# Altium schematic sheet printer.SchDoc
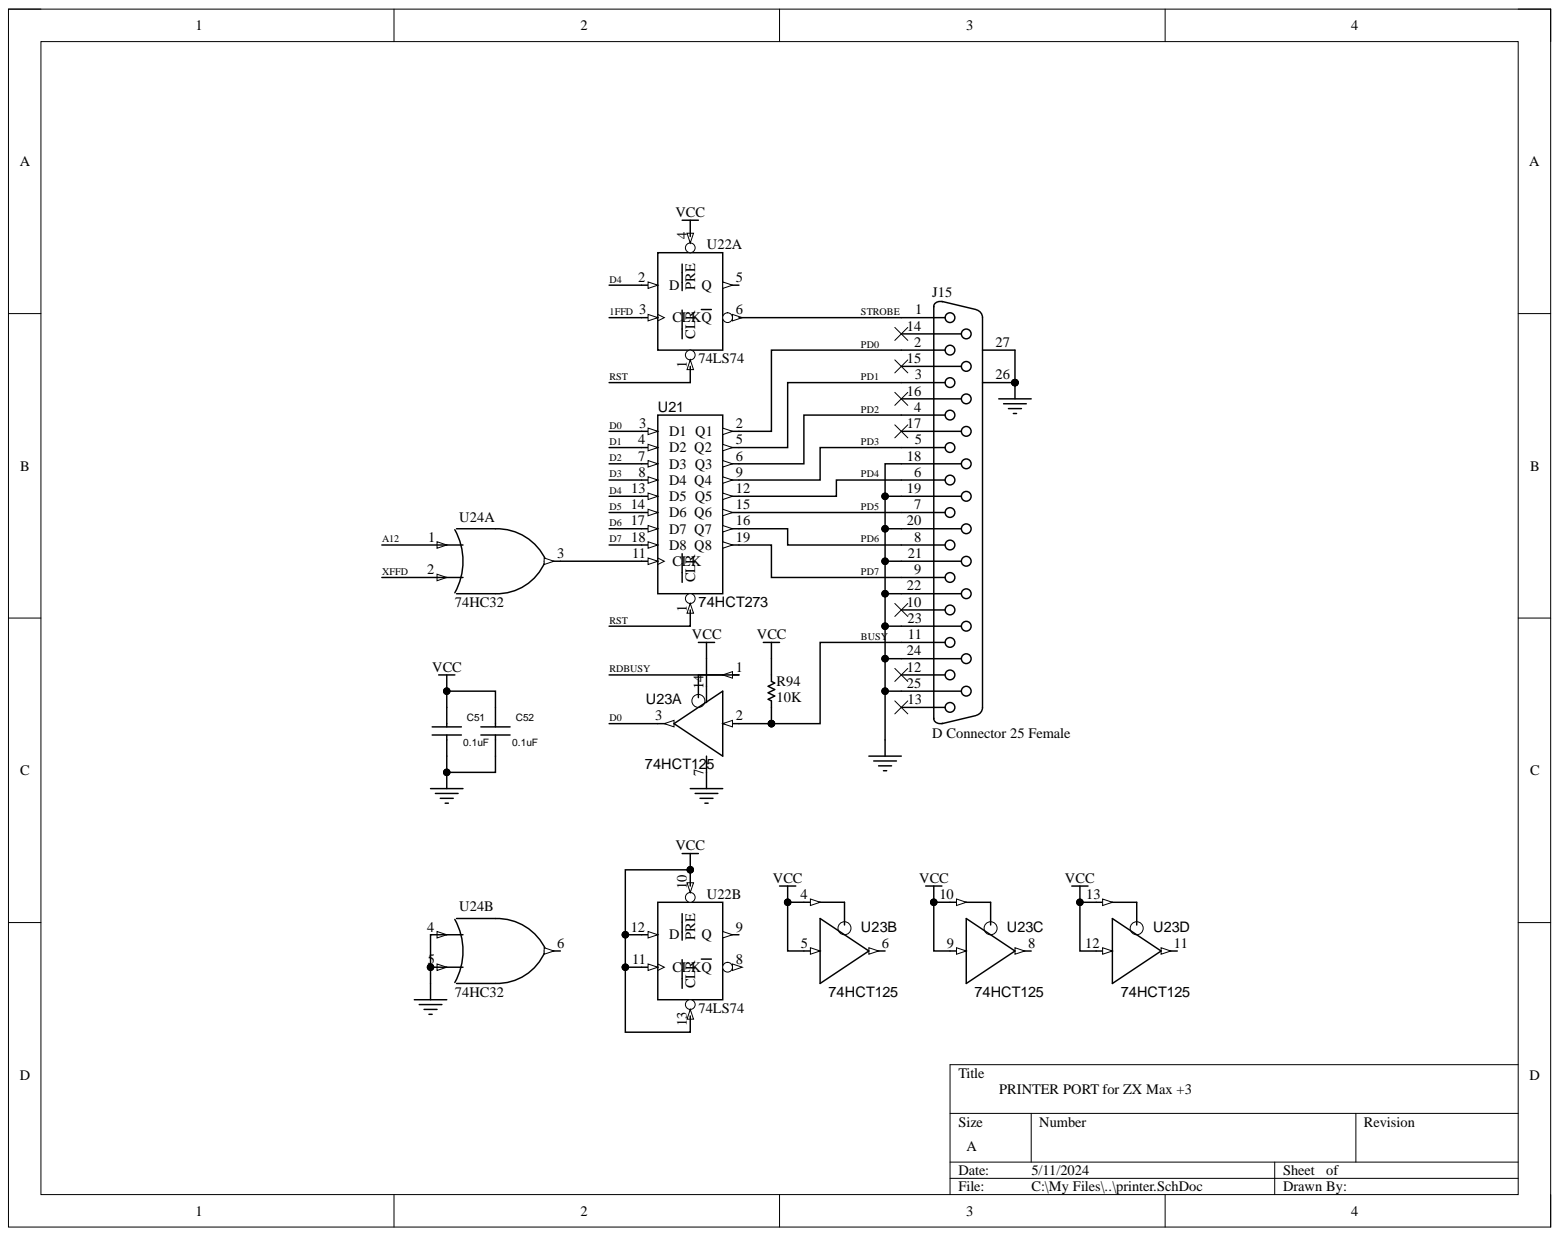
[diagram p0: printer.SchDoc]

## Parts
C51  0.1uF  [RAD/.200X.100/LS.100/.031]
C52  0.1uF  [RAD/.200X.100/LS.100/.031]
J15  D Connector 25 Female  [DSUB1.385-2H25A]
R94  10K  [AX/.350X.100/.034]
U21  74HCT273  [DIP.100/20/W.300/L.975]
U22  74LS74  [DIP.100/14/W.300/L.700]  (parts A,B)
U23  74HCT125  [DIP.100/14/W.300/L.700]  (parts A,B,C,D)
U24  74HC32  [DIP.100/14/W.300/L.700]  (parts A,B)

## Nets
GND: C51.2 C52.2 J15.18 J15.19 J15.20 J15.21 J15.22 J15.23 J15.24 J15.25 J15.26 J15.27 U21.10 U22.7 U23.7 U24.4 U24.5 U24.7
VCC: C51.1 C52.1 R94.1 U21.20 U22.4(PRE) U22.10(PRE) U22.11(CLK) U22.12(D) U22.13(CLR) U22.14 U23.4 U23.5 U23.9 U23.10 U23.12 U23.13 U23.14 U24.14
1FFD: U22.3(CLK)
A12: U24.1
BUSY: J15.11 R94.2 U23.2
D0: U21.3(D1) U23.3
D1: U21.4(D2)
D2: U21.7(D3)
D3: U21.8(D4)
D4: U21.13(D5) U22.2(D)
D5: U21.14(D6)
D6: U21.17(D7)
D7: U21.18(D8)
PD0: J15.2 U21.2(Q1)
PD1: J15.3 U21.5(Q2)
PD2: J15.4 U21.6(Q3)
PD3: J15.5 U21.9(Q4)
PD4: J15.6 U21.12(Q5)
PD5: J15.7 U21.15(Q6)
PD6: J15.8 U21.16(Q7)
PD7: J15.9 U21.19(Q8)
RDBUSY: U23.1
RST: U21.1(CLR) U22.1(CLR)
STROBE: J15.1 U22.6(Q)
XFFD: U24.2
NetU21_11: U21.11(CLK) U24.3

Not connected: J15 10 12 13 14 15 16 17; U22 5(Q) 8(Q) 9(Q); U23 6 8 11; U24 6

## Library data of the parts
C51 C52 = CAP NP: COMPFIXED: NO; COMPGROUP: 0; COMPHEIGHT: 0.000; COMPLOC: [6275.000,975.000]; COMPSIDE: TOP; FPLIST: RAD/.150X.100/LS.100/.031; COMPKEY: NO; COMPLOCKED: NO; Component Kind: Standard; COMPROT: 0; DECOUPLER: NO; MEMORY: NO
J15 = D Connector 25: Receptacle Assembly, 25 Position, Right Angle; PackageDocument: U; Positions: 25; Component Kind: Standard; DatasheetDocument: 19-Mar-1999; Gender: Receptacle; LatestRevisionDate: 17-Jul-2002; LatestRevisionNote: Re-released for DXP Platform.; Library Name: Miscellaneous Connectors.IntLib; Orientation: Right Angle; PackageReference: 787202; Published: 15-Jun-2001; Signal Integrity: Connector; Publisher: Altium Limited
R94 = Res1: Resistor; Component Kind: Standard; LatestRevisionDate: 17-Jul-2002; LatestRevisionNote: Re-released for DXP Platform.; Library Name: Miscellaneous Devices.IntLib; PackageReference: AXIAL-0.3; Published: 8-Jun-2000; Signal Integrity: Res; Simulation: RESISTOR; Value: 10K; Publisher: Altium Limited
U21 = 74HC273: IO_LEVEL: 0; PSpiceTemplate: X^@REFDES %C\L\R\ %CLK %D1 %D2 %D3 %D4 %D5 %D6 %D7 %D8 %Q1 %Q2 %Q3 %Q4 %Q5 %Q6 %Q7 %Q8 %VCC %GND @MODEL PARAMS:\n+ IO_LEVEL=@IO_LEVEL MNTYMXDLY=@MNTYMXDLY; Component Kind: Standard; MNTYMXDLY: 0; Simulation: 74HC273; Library Name: Retro Computer.SchLib
U22 = 74HC74: IO_LEVEL: 0; PSpiceTemplate: X^@REFDES %C\L\R\ %D %CLK %P\R\E\ %Q %Q\ %VCC %GND @MODEL PARAMS:\n+ IO_LEVEL=@IO_LEVEL MNTYMXDLY=@MNTYMXDLY; Component Kind: Standard; MNTYMXDLY: 0; Simulation: 74HC74; Library Name: Retro Computer.SchLib
U23 = 74HC125: IO_LEVEL: 0; PSpiceTemplate: X^@REFDES %A %G\ %Y %VCC %GND @MODEL PARAMS:\n+ IO_LEVEL=@IO_LEVEL MNTYMXDLY=@MNTYMXDLY; Component Kind: Standard; MNTYMXDLY: 0; Simulation: 74HC125; Library Name: allram.SchLib
U24 = 74HC32: IO_LEVEL: 0; PSpiceTemplate: X^@REFDES %A %B %Y %VCC %GND @MODEL PARAMS:\n+ IO_LEVEL=@IO_LEVEL MNTYMXDLY=@MNTYMXDLY; Component Kind: Standard; MNTYMXDLY: 0; Simulation: 74HC32; Library Name: HARLEQUIN REV G128_Library.SchLib
